# Altium schematic sheet imu-pcb.SchDoc
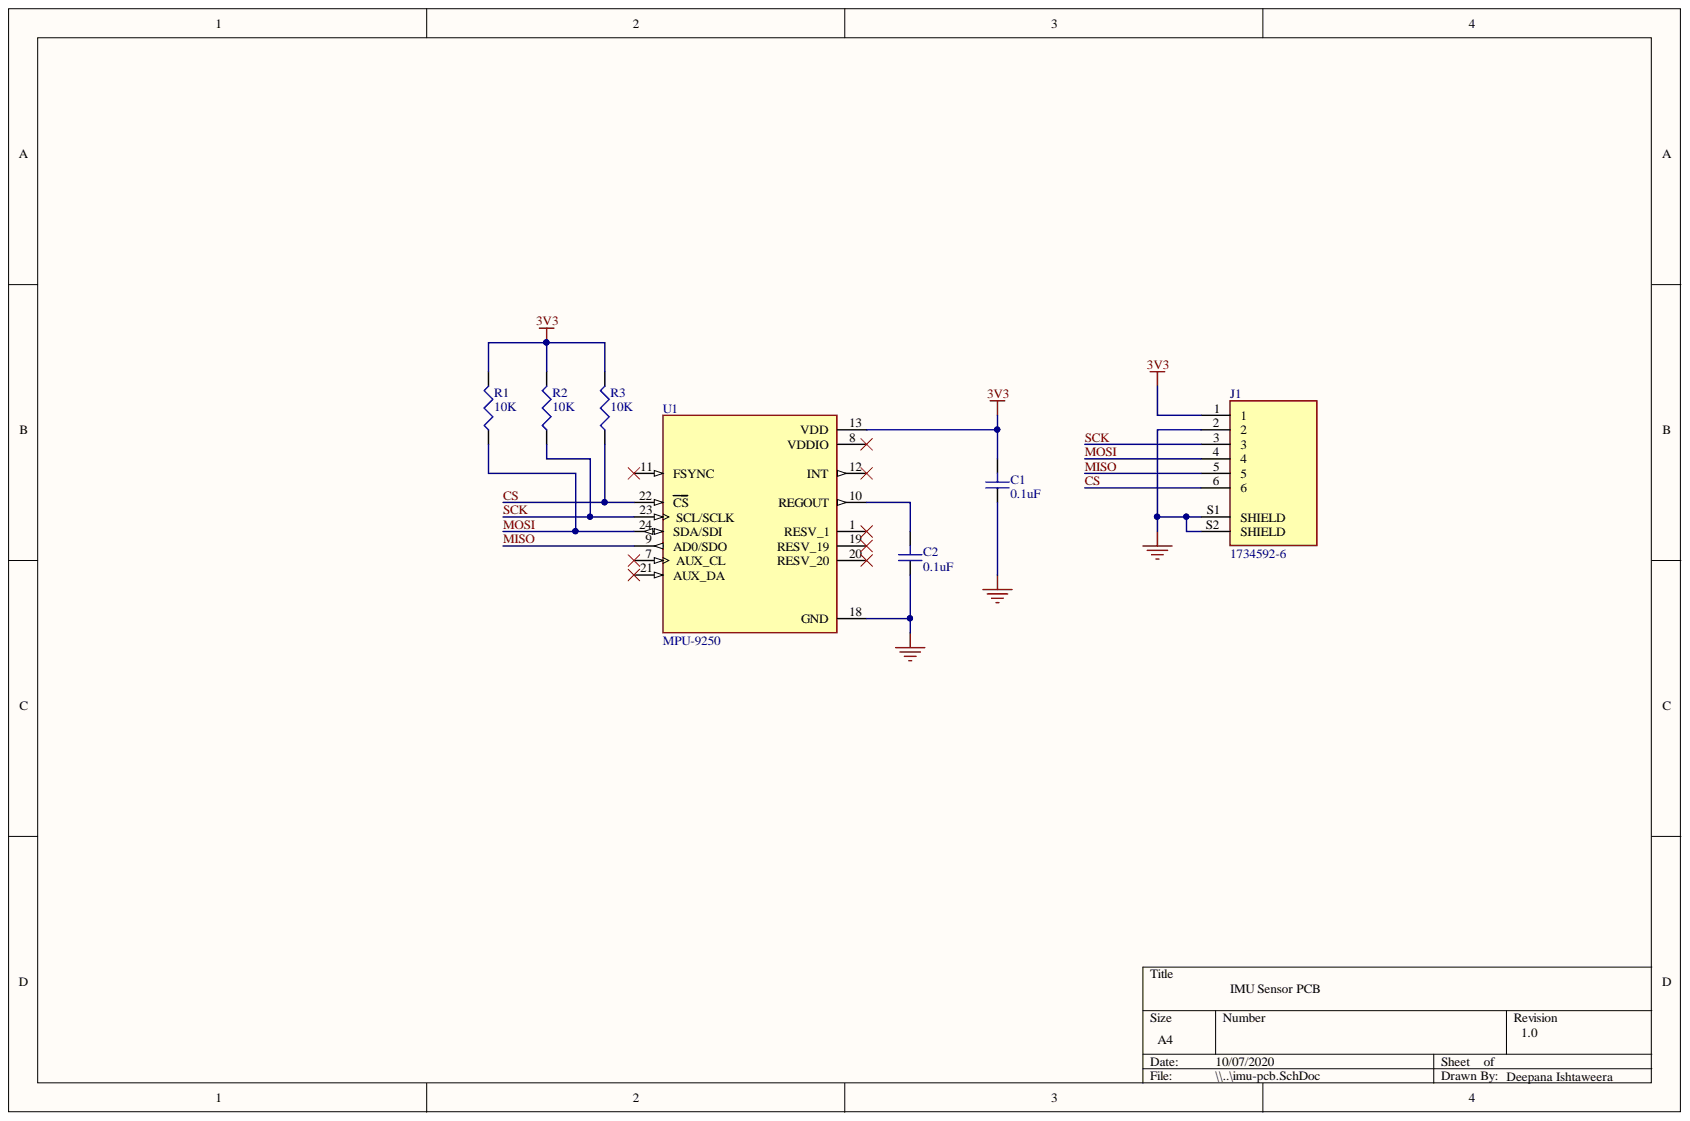
[diagram p0: imu-pcb.SchDoc]

## Parts
C1  0.1uF  [CAP (0805)]
C2  0.1uF  [CAP (0805)]
J1  1734592-6  [TE_1734592-6]
R1  10K  [Res (C0805)]
R2  10K  [Res (C0805)]
R3  10K  [Res (C0805)]
U1  MPU-9250  [QFN40P300X300X105-24N]

## Nets
3V3: C1.2 J1.1 R1.2 R2.2 R3.2 U1.13(VDD)
GND: C1.1 C2.1 J1.2 J1.S1(SHIELD) J1.S2(SHIELD) U1.18(GND)
CS: J1.6 R3.1 U1.22(CS)
MISO: J1.5 U1.9(AD0/SDO)
MOSI: J1.4 R1.1 U1.24(SDA/SDI)
SCK: J1.3 R2.1 U1.23(SCL/SCLK)
NetC2_2: C2.2 U1.10(REGOUT)

Not connected: U1 1(RESV_1) 7(AUX_CL) 8(VDDIO) 11(FSYNC) 12(INT) 19(RESV_19) 20(RESV_20) 21(AUX_DA)

## Library data of the parts
C1 C2 = Cap(Surface)_0.1uF: Capacitor; Component Kind: Standard; PackageDescription: Capacitor; 2 Leads; PackageReference: CAPR5-4X5; Published: 23-Sep-2002; Signal Integrity: Cap; Simulation: CAP; Supplier: LCSC; Supplier Part No: C105951; Value: 0.1uF; Library Name: imu-pcb-lib.SchLib; Publisher: Altium Limited
J1 = 1734592-6: 6 Position FPC Connector Contacts, Bottom 0.020 (0.50mm) Surface Mount, Right Angle; MF: TE Connectivity; Availability: Unavailable; Component Kind: Standard; MP: 1734592-6; Package: None; Price: None; SnapEDA_Link: https://www.snapeda.com/parts/1734592-6/TE%20Connectivity/view-part/4788517/?ref=snap; Library Name: imu-pcb-lib.SchLib
R1-R3 = Res(Surface)_10K: Resistor SMD 0805; Component Kind: Standard; LatestRevisionDate: 29-May-2009; LatestRevisionNote: IPC-7351 Footprint Added.; PackageDescription: Chip Resistor; PackageReference: J1-0603; Published: 8-Jun-2000; Signal Integrity: Res; Simulation: RESISTOR; Supplier: LCSC; Supplier Part No: C306152; Library Name: imu-pcb-lib.SchLib; Publisher: Altium Limited
U1 = MPU-9250: Accelerometer, Gyroscope, Magnetometer, 9 Axis Sensor IÂ²C, SPI Output; MF: TDK InvenSense; Availability: Unavailable; Component Kind: Standard; MP: MPU-9250; Package: TFQFN-24 TDK InvenSense; Price: None; SnapEDA_Link: https://www.snapeda.com/parts/MPU-9250/TDK%20InvenSense/view-part/4652549/?ref=snap; Library Name: imu-pcb-lib.SchLib
